# Altium schematic sheet Sheet2.SchDoc
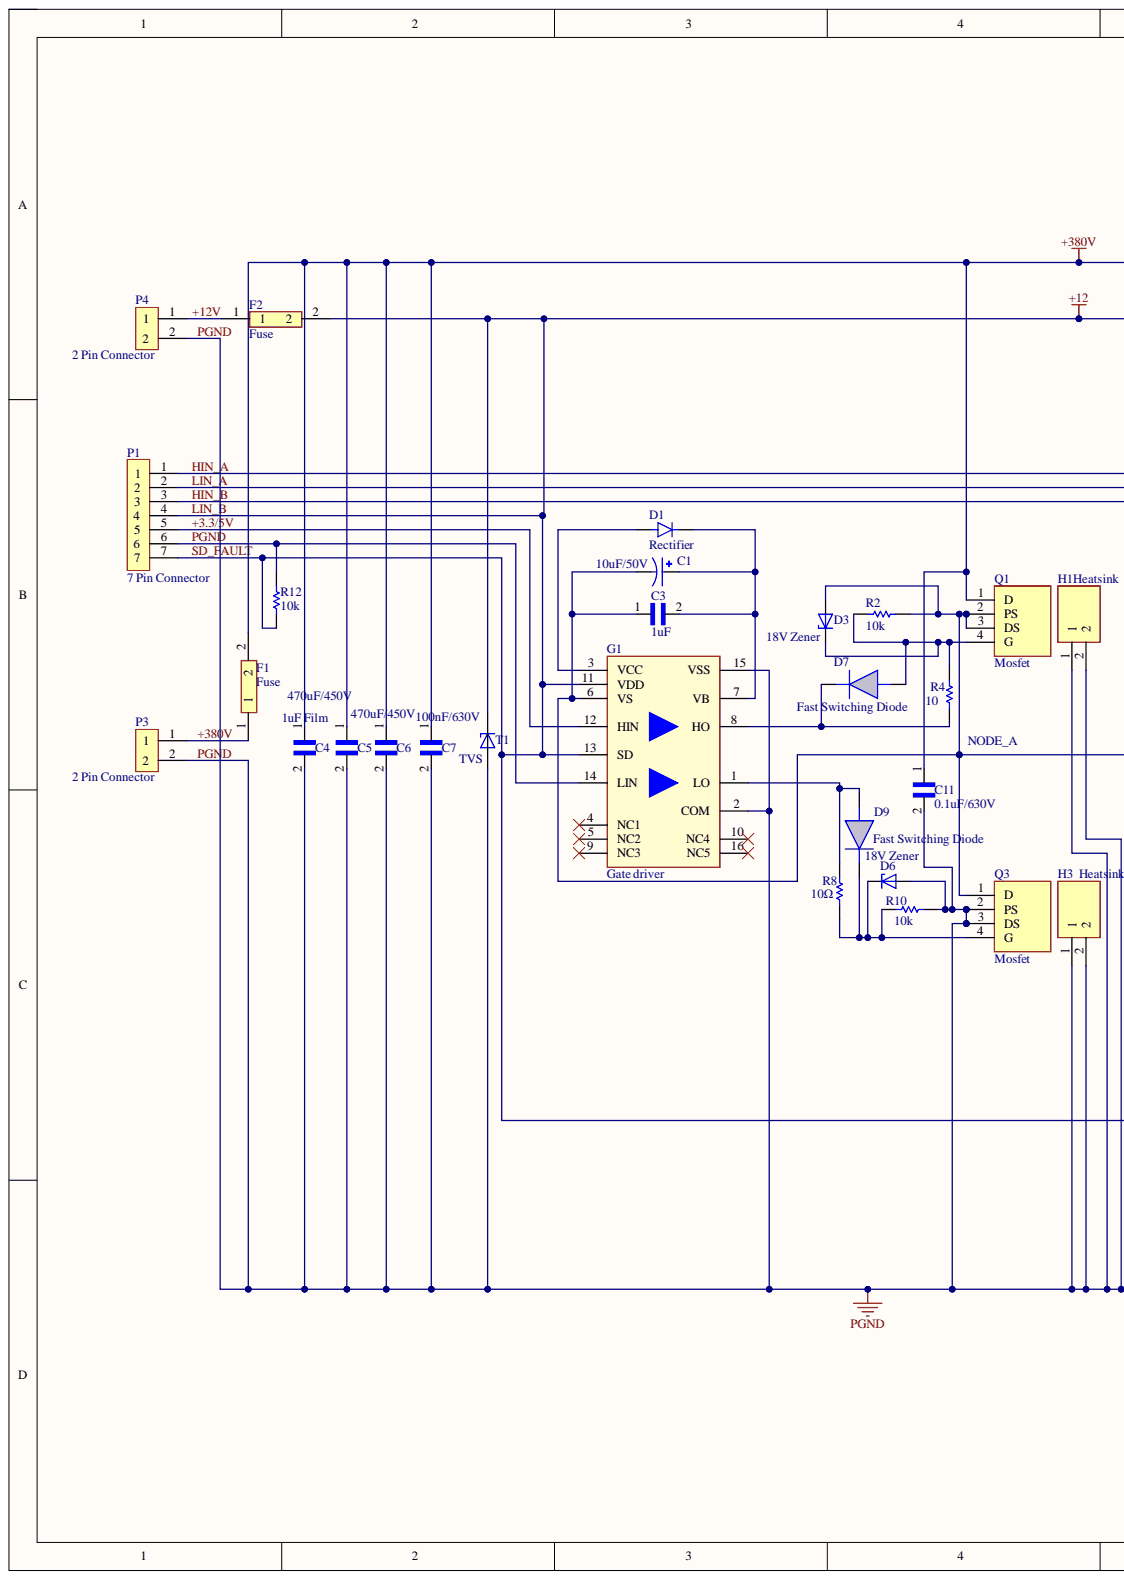
[diagram p0: Sheet2.SchDoc, left]
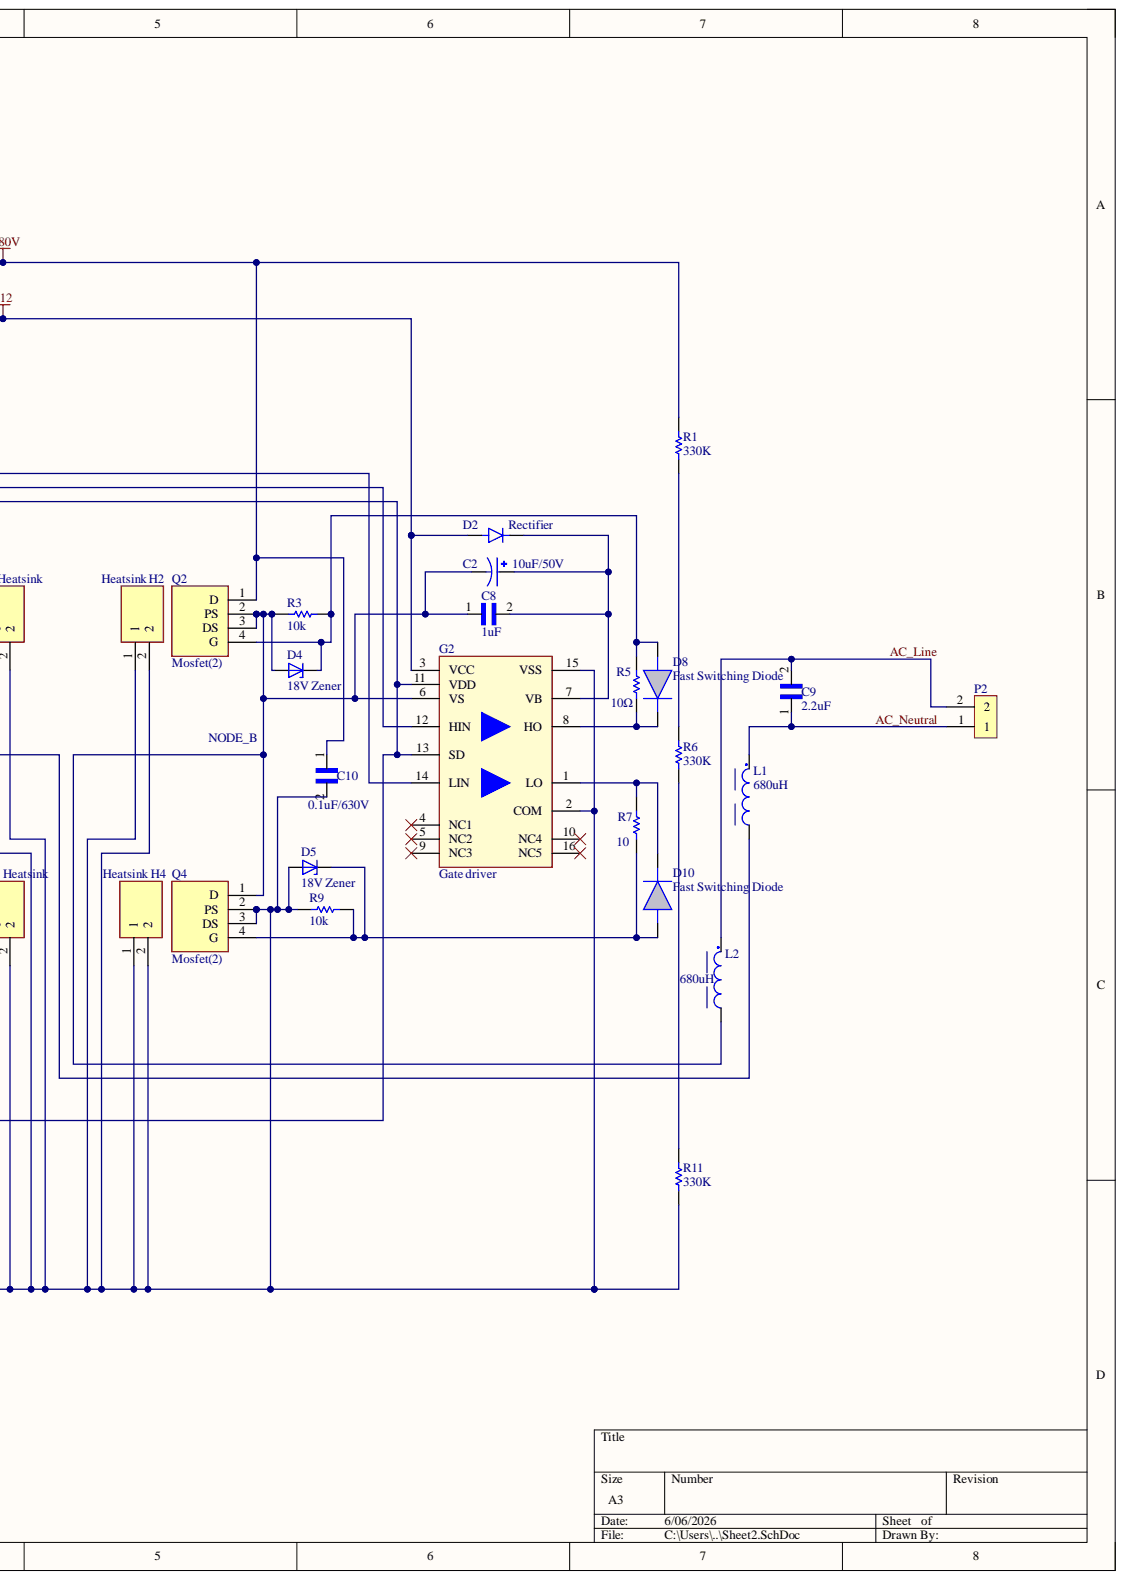
[diagram p1: Sheet2.SchDoc, right]

## Parts
C1  10uF/50V
C2  10uF/50V
C3  1uF
C4  1uF Film
C5  470uF/450V
C6  470uF/450V
C7  100nF/630V
C8  1uF
C9  2.2uF
C10  0.1uF/630V
C11  0.1uF/630V
D1  Rectifier
D2  Rectifier
D3  18V Zener
D4  18V Zener
D5  18V Zener
D6  18V Zener
D7  Fast Switching Diode
D8  Fast Switching Diode
D9  Fast Switching Diode
D10  Fast Switching Diode
F1  Fuse
F2  Fuse
G1  Gate driver
G2  Gate driver
H1  Heatsink
H2  Heatsink
H3  Heatsink
H4  Heatsink
L1  680uH  [Inductor]
L2  680uH  [Inductor]
P1  7 Pin Connector
P2  (no value)
P3  2 Pin Connector
P4  2 Pin Connector
Q1  Mosfet
Q2  Mosfet(2)
Q3  Mosfet
Q4  Mosfet(2)
R1  330K
R2  10k
R3  10k
R4  10
R5  10Ω
R6  330K
R7  10
R8  10Ω
R9  10k
R10  10k
R11  330K
R12  10k
T1  TVS

## Nets
+3.3/5V: G1.12(HIN) P1.5
+12V: F2.1 P4.1
+380V: C4.1 C5.1 C6.1 C7.1 C10.1 C11.1 F1.1 F1.2 P3.1 Q1.1(D) Q2.1(D) R1.2
AC_Line: C9.2 L2.2 P2.2
AC_Neutral: C9.1 L1.2 P2.1
HIN_A: G2.14(LIN) P1.1
HIN_B: D2.A F2.2 G1.11(VDD) G1.13(SD) G2.3(VCC) G2.11(VDD) G2.13(SD) P1.3 P1.4 P1.7 R12.1 T1.C
LIN_A: G2.12(HIN) P1.2
PGND: C4.2 C5.2 C6.2 C7.2 C10.2 C11.2 D5.2 D6.2 G1.2(COM) G1.14(LIN) G1.15(VSS) G2.2(COM) G2.15(VSS) H1.1 H1.2 H2.1 H2.2 H3.1 H3.2 H4.1 H4.2 P1.6 P3.2 P4.2 Q3.2(PS) Q3.3(DS) Q4.2(PS) Q4.3(DS) R9.1 R10.2 R11.1 R12.2 T1.A
NetC1_N: C1.N C3.1 D3.2 G1.6(VS) L1.1 Q1.2(PS) Q1.3(DS) Q3.1(D) R2.2
NetC1_P: C1.P C3.2 D1.C G1.7(VB)
NetC2_N: C2.N C8.1 D4.2 G2.6(VS) L2.1 Q2.2(PS) Q2.3(DS) Q4.1(D) R3.1
NetC2_P: C2.P C8.2 D2.C G2.7(VB)
NetD1_A: D1.A G1.3(VCC)
NetD3_1: D3.1 D7.2 Q1.4(G) R2.1 R4.2
NetD4_1: D4.1 D8.2 Q2.4(G) R3.2 R5.2
NetD5_1: D5.1 D10.2 Q4.4(G) R7.1 R9.2
NetD6_1: D6.1 D9.1 Q3.4(G) R8.1 R10.1
NetD7_1: D7.1 G1.8(HO) R4.1
NetD8_1: D8.1 G2.8(HO) R5.1
NetD9_2: D9.2 G1.1(LO) R8.2
NetD10_1: D10.1 G2.1(LO) R7.2
NetR1_1: R1.1 R6.2
NetR6_1: R6.1 R11.2

Not connected: G1 4(NC1) 5(NC2) 9(NC3) 10(NC4) 16(NC5); G2 4(NC1) 5(NC2) 9(NC3) 10(NC4) 16(NC5)

## Library data of the parts
C1 C2 = 10uF/50V: ECA-1HM100B; Component Kind: Standard; Library Name: Schlib2.SchLib
C9 = 2.2uF: ECWFE2W225KA; Component Kind: Standard; Library Name: Schlib2.SchLib
C10 C11 = 0.1uF/630V: ECW-FE2J104K; Component Kind: Standard; Library Name: Schlib2.SchLib
D1 D2 = Rectifier: MUR160G; Component Kind: Standard; Library Name: Schlib2.SchLib
D3-D6 = Zener Diode: BZT52C18; Component Kind: Standard; Library Name: Schlib2.SchLib
D7-D10 = Fast Switching Diode: 1N4148W-7-F; Component Kind: Standard; Library Name: Schlib2.SchLib
G1 G2 = Gate driver: IR2110; Component Kind: Standard; Library Name: Schlib2.SchLib
L1 L2 = Inductor: 1140-681K-RC; Component Kind: Standard; Library Name: Schlib2.SchLib
P2 = 2 Pin Connector: Component Kind: Standard; Library Name: Schlib2.SchLib
Q1 Q3 = Mosfet: C3M0045065K; Component Kind: Standard; Library Name: Schlib2.SchLib
Q2 Q4 = Mosfet(2): C3M0045065K; Component Kind: Standard; Library Name: Schlib2.SchLib
R4 R7 = 10Ω: Component Kind: Standard; Library Name: Schlib2.SchLib
T1 = TVS: SMAJ15A; Component Kind: Standard; Library Name: Schlib2.SchLib
C3 C8 = 1uF: Component Kind: Standard; Library Name: Schlib2.SchLib
C4 = 1uF Film: Component Kind: Standard; Library Name: Schlib2.SchLib
C5 C6 = 470uF/450V: Component Kind: Standard; Library Name: Schlib2.SchLib
C7 = 100nF/630V: Component Kind: Standard; Library Name: Schlib2.SchLib
F1 F2 = Fuse: Component Kind: Standard; Library Name: Schlib2.SchLib
H1-H4 = Heatsink: Component Kind: Standard; Library Name: Schlib2.SchLib
P1 = 7 Pin Connector: Component Kind: Standard; Library Name: Schlib2.SchLib
P3 P4 = 2 Pin Connector: Component Kind: Standard; Library Name: Schlib2.SchLib
R1 R6 R11 = 330K: Component Kind: Standard; Library Name: Schlib2.SchLib
R2 R3 R9 R10 R12 = 10k: Component Kind: Standard; Library Name: Schlib2.SchLib
R5 R8 = 10Ω: Component Kind: Standard; Library Name: Schlib2.SchLib
